# Altium schematic sheet WK2124_DBoard_V1.0.SchDoc
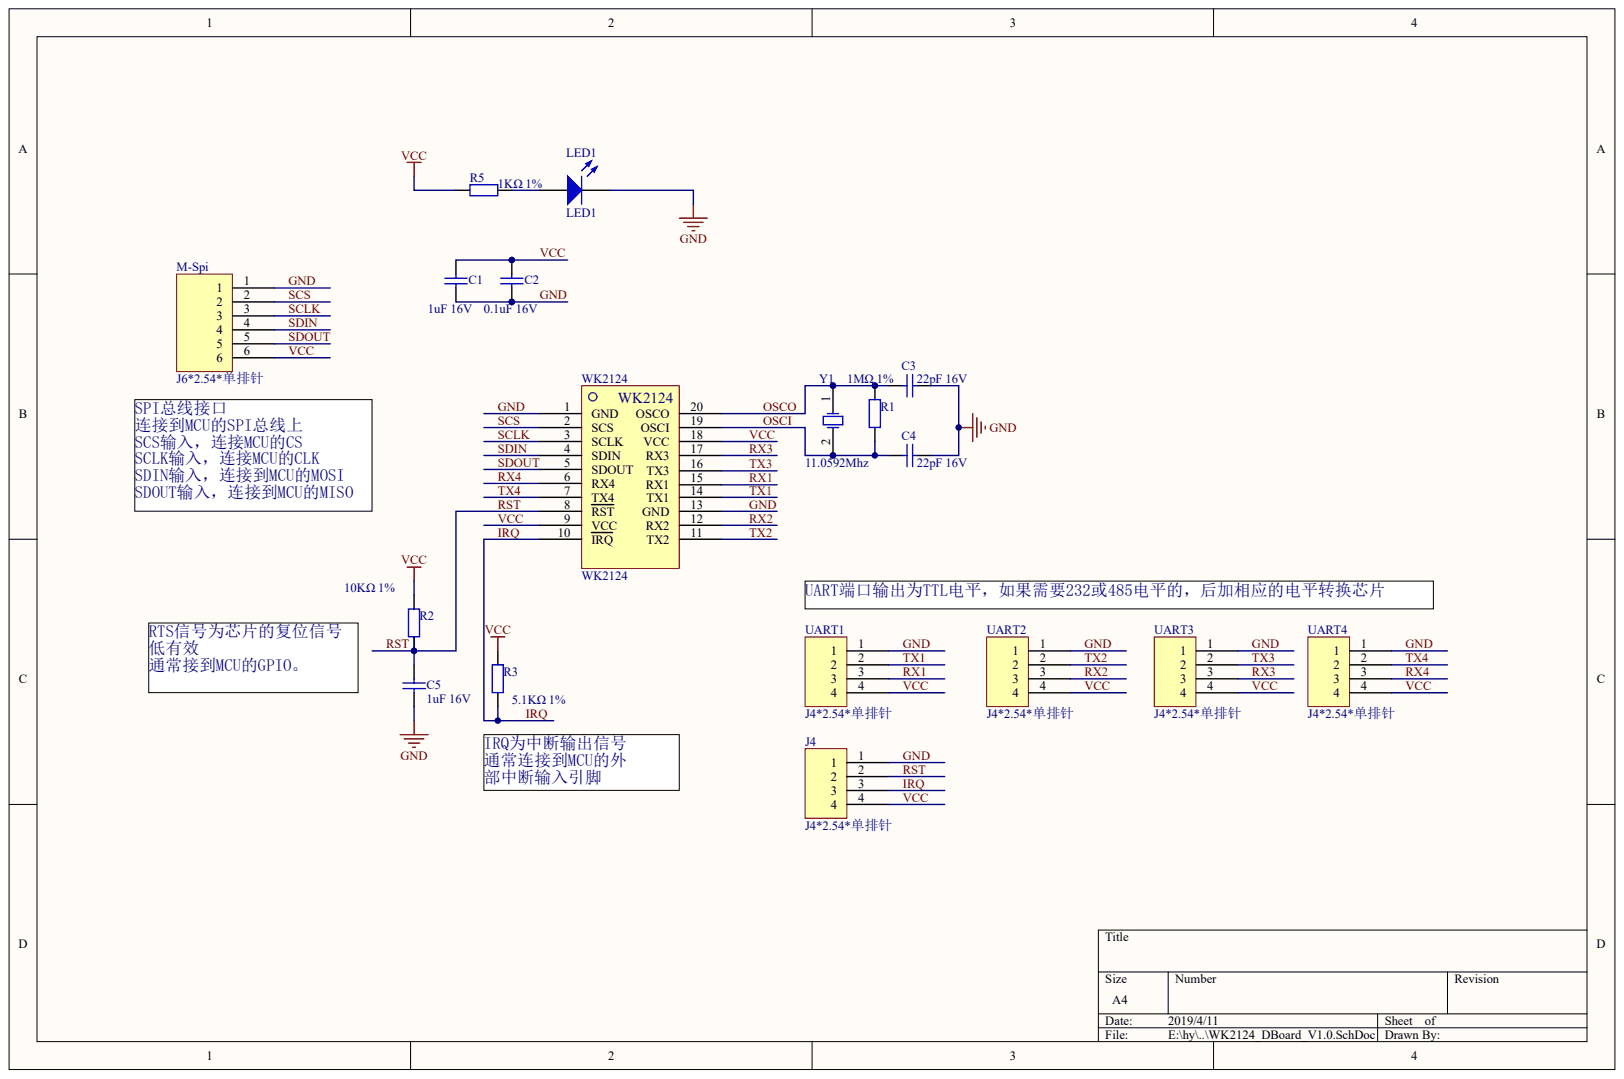
[diagram p0: WK2124_DBoard_V1.0.SchDoc]

## Parts
C1  1uF 16V  value 1uF
C2  0.1uF 16V
C3  22pF 16V  value 22pF
C4  22pF 16V  value 22pF
C5  1uF 16V  value 1uF
J4  J4*2.54*单排针
LED1  LED1
M-Spi  J6*2.54*单排针
R1  1MΩ ±1%  (value not drawn)
R2  10KΩ ±1%  (value not drawn)
R3  5.1KΩ ±1%  (value not drawn)
R5  1KΩ ±1%  (value not drawn)
UART1  J4*2.54*单排针
UART2  J4*2.54*单排针
UART3  J4*2.54*单排针
UART4  J4*2.54*单排针
WK2124  WK2124
Y1  value 11.0592Mhz  (hidden comment: XTAL*11.0592Mhz)

## Nets
GND: C1.1 C2.1 C3.2 C4.2 C5.1 J4.1 LED1.2 M-Spi.1 UART1.1 UART2.1 UART3.1 UART4.1 WK2124.1(GND) WK2124.13(GND)
IRQ: J4.3 R3.2 WK2124.10(IRQ)
OSCI: C4.1 R1.1 WK2124.19(OSCI) Y1.2
OSCO: C3.1 R1.2 WK2124.20(OSCO) Y1.1
RST: C5.2 J4.2 R2.1 WK2124.8(RST)
RX1: UART1.3 WK2124.15(RX1)
RX2: UART2.3 WK2124.12(RX2)
RX3: UART3.3 WK2124.17(RX3)
RX4: UART4.3 WK2124.6(RX4)
SCLK: M-Spi.3 WK2124.3(SCLK)
SCS: M-Spi.2 WK2124.2(SCS)
SDIN: M-Spi.4 WK2124.4(SDIN)
SDOUT: M-Spi.5 WK2124.5(SDOUT)
TX1: UART1.2 WK2124.14(TX1)
TX2: UART2.2 WK2124.11(TX2)
TX3: UART3.2 WK2124.16(TX3)
TX4: UART4.2 WK2124.7(TX4)
VCC: C1.2 C2.2 J4.4 M-Spi.6 R2.2 R3.1 R5.1 UART1.4 UART2.4 UART3.4 UART4.4 WK2124.9(VCC) WK2124.18(VCC)
NetLED1_1: LED1.1 R5.2

## Library data of the parts
C2 = (no symbol name): Value: 0.01uF; Published: 8-Jun-2000; LatestRevisionDate: 17-Jul-2002; LatestRevisionNote: Re-released for DXP Platform.; PackageReference: RAD-0.3; Publisher: Altium Limited
R1 = (no symbol name): Value: 1M; Published: 8-Jun-2000; LatestRevisionDate: 17-Jul-2002; LatestRevisionNote: Re-released for DXP Platform.; PackageReference: AXIAL-0.4; Publisher: Altium Limited
R2 = (no symbol name): Value: 10K; Published: 8-Jun-2000; LatestRevisionDate: 17-Jul-2002; LatestRevisionNote: Re-released for DXP Platform.; PackageReference: AXIAL-0.4; Publisher: Altium Limited
R3 = (no symbol name): Value: 5.1K; Published: 8-Jun-2000; LatestRevisionDate: 17-Jul-2002; LatestRevisionNote: Re-released for DXP Platform.; PackageReference: AXIAL-0.4; Publisher: Altium Limited
R5 = (no symbol name): Value: 1K; Published: 8-Jun-2000; LatestRevisionDate: 17-Jul-2002; LatestRevisionNote: Re-released for DXP Platform.; PackageReference: AXIAL-0.4; Publisher: Altium Limited
C1 C3-C5 J4 LED1 M-Spi UART1-UART4 WK2124 Y1 = (no symbol name)
  C1 C3-C5: Published: 8-Jun-2000; LatestRevisionDate: 17-Jul-2002; LatestRevisionNote: Re-released for DXP Platform.; PackageReference: RAD-0.3
  LED1: Published: 8-Jun-2000; LatestRevisionDate: 17-Jul-2002; LatestRevisionNote: Re-released for DXP Platform.; PackageVersion: Feb-2002; PackageReference: 3.2X1.6X1.1; PackageDescription: SM LED; 2 Flat Leads
  Y1: Published: 8-Jun-2000; LatestRevisionDate: 17-Jul-2002; LatestRevisionNote: Re-released for DXP Platform.; PackageReference: R38
  C1 C3-C5 LED1 Y1: Publisher: Altium Limited
